# Altium schematic sheet Motor_Driver.SchDoc(Motor_Driver)
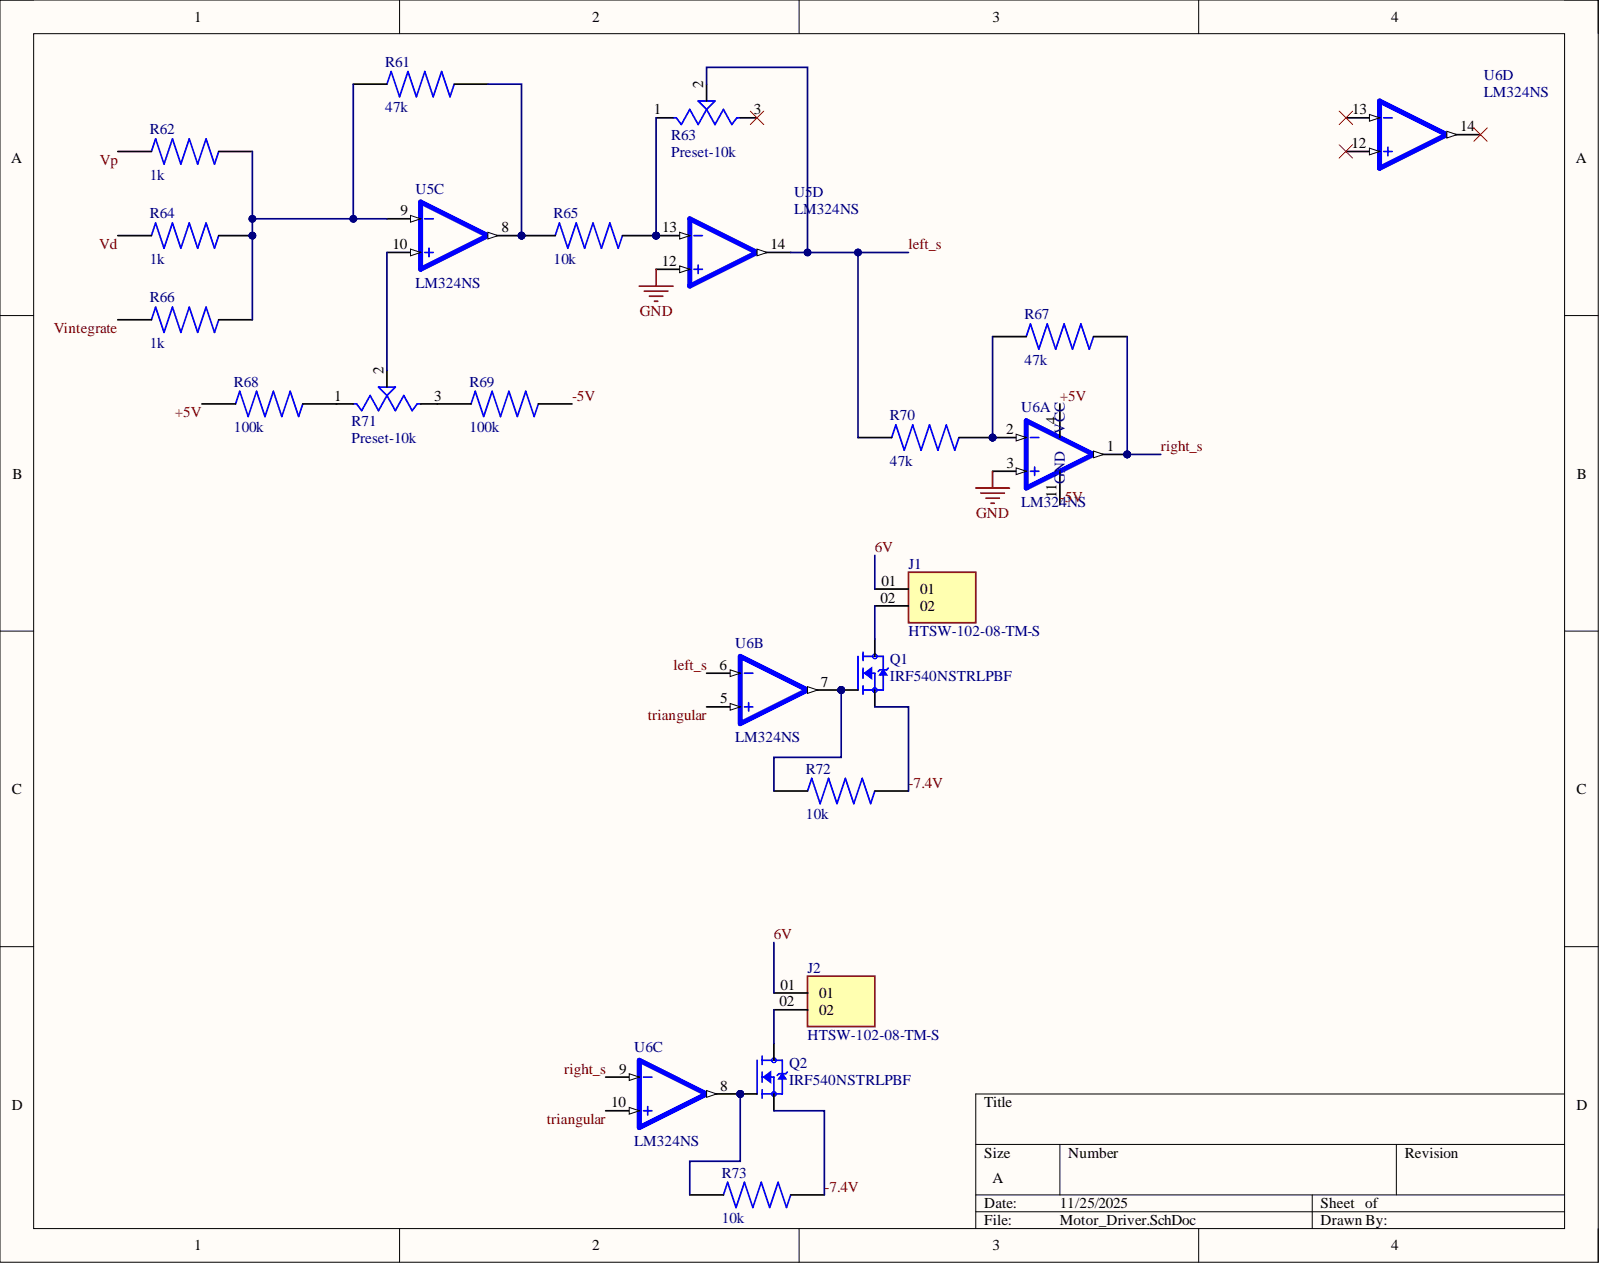
[diagram p0: Motor_Driver.SchDoc]

## Parts
J1  HTSW-102-08-TM-S
J2  HTSW-102-08-TM-S
Q1  IRF540NSTRLPBF
Q2  IRF540NSTRLPBF
R61  47k
R62  1k
R63  Preset-10k
R64  1k
R65  10k
R66  1k
R67  47k
R68  100k
R69  100k
R70  47k
R71  Preset-10k
R72  10k
R73  10k
U5  LM324NS  (parts C,D)
U6  LM324NS  (parts A,B,C,D)

## Nets
GND: U5.12 U6.3
6V: J1.01 J2.01
+5V: R68.1 U6.4(GND)
-5V: R69.2 U6.11
-7.4V: Q1.3 Q2.3 R72.2 R73.2
left_s: R63.2 R70.1 U5.14 U6.6
right_s: R67.2 U6.1 U6.9
triangular: U6.5 U6.10
Vd: R64.1
Vintegrate: R66.1
Vp: R62.1
NetJ1_02: J1.02 Q1.2
NetJ2_02: J2.02 Q2.2
NetQ1_1: Q1.1 R72.1 U6.7
NetQ2_1: Q2.1 R73.1 U6.8
NetR61_1: R61.1 R62.2 R64.2 R66.2 U5.9
NetR61_2: R61.2 R65.1 U5.8
NetR63_1: R63.1 R65.2 U5.13
NetR67_1: R67.1 R70.2 U6.2
NetR68_2: R68.2 R71.1
NetR69_1: R69.1 R71.3
NetR71_2: R71.2 U5.10

Not connected: R63 3; U6 12 13 14

## Library data of the parts
J1 J2 = HTSW-102-08-TM-S: HEADER, 2 CONTACTS, 1 ROW, THROUGH HOLE, 2.54 MM; Connector Systems:Board-to-Board; Pitch Spacing:2.54mm; No. of Rows:1Rows; No. of Contacts:2Contacts; Contact Termination Type:Through Hole; Product Range:HTSW Series; Gender:Header RoHS Compliant: Yes; MF: Samtec; MP: HTSW10208TMS; Availability: In Stock; Check_prices: https://www.snapeda.com/parts/HTSW10208TMS/Samtec/view-part/?ref=eda; Component Kind: Standard; Library Name: 2 pin connector-2.IntLib; Package: None; Price: None; SnapEDA_Link: https://www.snapeda.com/parts/HTSW10208TMS/Samtec/view-part/?ref=snap
Q1 Q2 = IRF540NSTRLPBF: MOSFET, Power,N-Ch,VDSS 100V,RDS(ON) 44 Milliohms,ID 33A,D2Pak,PD 130W,VGS+/-20V | Infineon IRF540NSTRLPBF; Check_prices: https://www.snapeda.com/parts/IRF540NSTRLPBF/Infineon/view-part/?ref=eda; Component Kind: Standard; Library Name: mosfet 2.IntLib; MF: Infineon; MP: IRF540NSTRLPBF; Package: D2PAK Infineon; SnapEDA_Link: https://www.snapeda.com/parts/IRF540NSTRLPBF/Infineon/view-part/?ref=snap
R61 R67 R70 = 47k: 47 kOhms Â±1% 0.125W, 1/8W Chip Resistor 0805 (2012 Metric) Moisture Resistant Thick Film; RC0805FR-0747KL; MF: Yageo; Check_prices: https://www.snapeda.com/parts/RC0805FR-0747KL/Yageo/view-part/?ref=eda; Component Kind: Standard; Library Name: 47ks.IntLib; MP: RC0805FR-0747KL; Package: 2012 Yageo; SnapEDA_Link: https://www.snapeda.com/parts/RC0805FR-0747KL/Yageo/view-part/?ref=snap
R62 R64 R66 = 1k: 1 kOhms Â±1% 0.125W, 1/8W Chip Resistor 0805 (2012 Metric) Moisture Resistant Thick Film; RC0805FR-071KL; MF: Yageo; Check_prices: https://www.snapeda.com/parts/RC0805FR-071KL/Yageo/view-part/?ref=eda; Component Kind: Standard; DigiKey_Part_Number: 311-1.00KCRTR-ND; Library Name: 1ks.IntLib; MP: RC0805FR-071KL; Package: 2012 Yageo; Purchase-URL: https://pricing.snapeda.com/search?q=RC0805FR-071KL&ref=eda; SnapEDA_Link: https://www.snapeda.com/parts/RC0805FR-071KL/Yageo/view-part/?ref=snap
R63 R71 = Preset: TRIMMER - 6MM ROUND, SINGLE-TURN,CERMET, 10K OHMS | Bourns 3306F-1-103; Check_prices: https://www.snapeda.com/parts/3306F-1-103/Bourns/view-part/?ref=eda; Component Kind: Standard; Library Name: 3306F-1-103.IntLib; MF: Bourns; MP: 3306F-1-103; Package: 3306F Bourns; SnapEDA_Link: https://www.snapeda.com/parts/3306F-1-103/Bourns/view-part/?ref=snap
R65 R72 R73 = 10k: 10 kOhms Â±1% 0.125W, 1/8W Chip Resistor 0805 (2012 Metric) Moisture Resistant Thick Film; RC0805FR-0710KL; MF: Yageo; Availability: In Stock; Check_prices: https://www.snapeda.com/parts/RC0805FR-0710KL/Yageo/view-part/?ref=eda; Component Kind: Standard; Library Name: 10ks.IntLib; MP: RC0805FR-0710KL; Package: 2012 Rohm Semiconductor; Price: None; Purchase-URL: https://pricing.snapeda.com/search/part/RC0805FR-0710KL/?ref=eda; SnapEDA_Link: https://www.snapeda.com/parts/RC0805FR-0710KL/Yageo/view-part/?ref=snap
R68 R69 = 100k: 100 kOhms Â±1% 0.125W, 1/8W Chip Resistor 0805 (2012 Metric) Moisture Resistant Thick Film; RC0805FR-07100KL; MF: Yageo; Check_prices: https://www.snapeda.com/parts/RC0805FR-07100KL/Yageo/view-part/?ref=eda; Component Kind: Standard; Library Name: 100ks.IntLib; MP: RC0805FR-07100KL; Package: 2012 Yageo; SnapEDA_Link: https://www.snapeda.com/parts/RC0805FR-07100KL/Yageo/view-part/?ref=snap
U5 U6 = LM324NS: 4-Channel industry standard operational amplifier 14-SO 0 to 70; LM324NSRG4; MF: Texas Instruments; Availability: In Stock; Check_prices: https://www.snapeda.com/parts/LM324NSRG4/Texas+Instruments/view-part/?ref=eda; Component Kind: Standard; Library Name: LM324NSRG4.IntLib; MP: LM324NSRG4; Package: SOP-14 Texas Instruments; Price: None; Purchase-URL: https://pricing.snapeda.com/search/part/LM324NSRG4/?ref=eda; SnapEDA_Link: https://www.snapeda.com/parts/LM324NSRG4/Texas+Instruments/view-part/?ref=snap
